# Altium schematic sheet Carte.SchDoc(Carte)
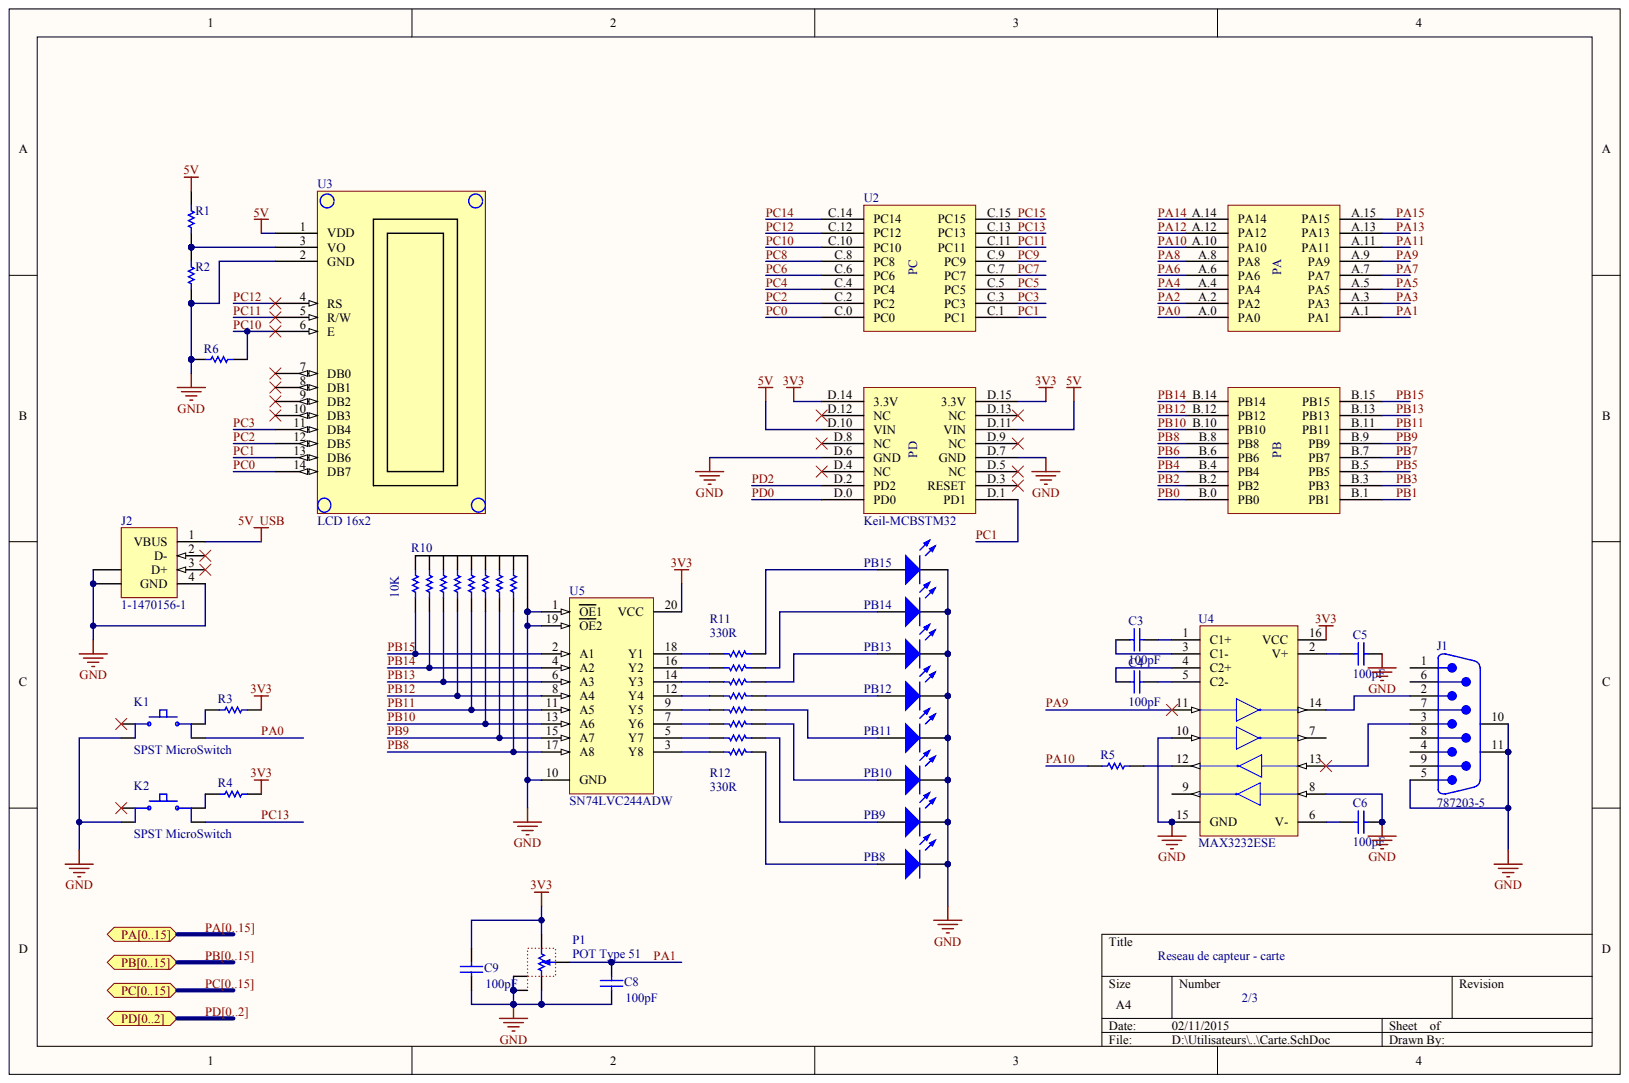
[diagram p0: Carte.SchDoc]

## Parts
C3  value 100pF  (hidden comment: C1206)
C4  value 100pF  (hidden comment: C1206)
C5  value 100pF  (hidden comment: C1206)
C6  value 100pF  (hidden comment: C1206)
C8  value 100pF  (hidden comment: C1206)
C9  value 100pF  (hidden comment: C1206)
J1  787203-5
J2  1-1470156-1
K1  SPST MicroSwitch
K2  SPST MicroSwitch
P1  POT Type 51
PB8  Led  (value not drawn)
PB9  Led  (value not drawn)
PB10  Led  (value not drawn)
PB11  Led  (value not drawn)
PB12  Led  (value not drawn)
PB13  Led  (value not drawn)
PB14  Led  (value not drawn)
PB15  Led  (value not drawn)
R1  ERJ8EN  (value not drawn)
R2  ERJ8EN  (value not drawn)
R3  ERJ8EN  (value not drawn)
R4  ERJ8EN  (value not drawn)
R5  ERJ8EN  (value not drawn)
R6  ERJ8EN  (value not drawn)
R10  value 10K  (hidden comment: Reseau-R Bus)
R11  value 330R  (hidden comment: Reseau-R Parallele)
R12  value 330R  (hidden comment: Reseau-R Parallele)
U2  Keil-MCBSTM32
U3  LCD 16x2
U4  MAX3232ESE
U5  SN74LVC244ADW

## Ports
PA0, PA1, PA2, PA3, PA4, PA5, PA6, PA7, PA8, PA9, PA10, PA11, PA12, PA13, PA14, PA15, PA[0..15], PB0, PB1, PB2, PB3, PB4, PB5, PB6, PB7, PB8, PB9, PB10, PB11, PB12, PB13, PB14, PB15, PB[0..15], PC0, PC1, PC2, PC3, PC4, PC5, PC6, PC7, PC8, PC9, PC10, PC11, PC12, PC13, PC14, PC15, PC[0..15], PD0, PD1, PD2, PD[0..2]

## Nets
3V3: C9.2 P1.1 R3.1 R4.1 U2.D.14(3.3V) U2.D.15 U4.16(VCC) U5.20(VCC)
5V: R1.2 U2.D.10(VIN) U2.D.11 U3.1(VDD)
5V_USB: J2.1(VBUS)
GND: C5.1 C6.1 C8.1 C9.1 J1.5 J1.10 J1.11 J2.4(GND) J2.5 J2.6 K1.2 K2.2 P1.3 P1.4 P1.5 PB8.K PB9.K PB10.K PB11.K PB12.K PB13.K PB14.K PB15.K R2.1 R6.1 R10.1 U2.D.6(GND) U2.D.7 U3.2(GND) U4.8 U4.10 U4.15(GND) U5.1(OE1) U5.10(GND) U5.19(OE2)
PA0: K1.4 U2.A.0(PA0) ; port PA[0..15]  [also labelled PA]
PA1: C8.2 P1.2 U2.A.1(PA1) ; port PA[0..15]  [also labelled PA]
PA2: U2.A.2(PA2) ; port PA[0..15]  [also labelled PA]
PA3: U2.A.3(PA3) ; port PA[0..15]  [also labelled PA]
PA4: U2.A.4 ; port PA[0..15]  [also labelled PA]
PA5: U2.A.5(PA5) ; port PA[0..15]  [also labelled PA]
PA6: U2.A.6 ; port PA[0..15]  [also labelled PA]
PA7: U2.A.7(PA7) ; port PA[0..15]  [also labelled PA]
PA8: U2.A.8 ; port PA[0..15]  [also labelled PA]
PA9: U2.A.9(PA9) U4.11 ; port PA[0..15]  [also labelled PA]
PA10: R5.2 U2.A.10 ; port PA[0..15]  [also labelled PA]
PA11: U2.A.11(PA11) ; port PA[0..15]  [also labelled PA]
PA12: U2.A.12 ; port PA[0..15]  [also labelled PA]
PA13: U2.A.13(PA13) ; port PA[0..15]  [also labelled PA]
PA14: U2.A.14 ; port PA[0..15]  [also labelled PA]
PA15: U2.A.15(PA15) ; port PA[0..15]  [also labelled PA]
PB0: U2.B.0 ; port PB[0..15]  [also labelled PB]
PB1: U2.B.1(PB1) ; port PB[0..15]  [also labelled PB]
PB2: U2.B.2 ; port PB[0..15]  [also labelled PB]
PB3: U2.B.3(PB3) ; port PB[0..15]  [also labelled PB]
PB4: U2.B.4 ; port PB[0..15]  [also labelled PB]
PB5: U2.B.5(PB5) ; port PB[0..15]  [also labelled PB]
PB6: U2.B.6 ; port PB[0..15]  [also labelled PB]
PB7: U2.B.7(PB7) ; port PB[0..15]  [also labelled PB]
PB8: R10.2 U2.B.8 U5.17(A8) ; port PB[0..15]  [also labelled PB]
PB9: R10.3 U2.B.9(PB9) U5.15(A7) ; port PB[0..15]  [also labelled PB]
PB10: R10.4 U2.B.10 U5.13(A6) ; port PB[0..15]  [also labelled PB]
PB11: R10.5 U2.B.11(PB11) U5.11(A5) ; port PB[0..15]  [also labelled PB]
PB12: R10.6 U2.B.12 U5.8(A4) ; port PB[0..15]  [also labelled PB]
PB13: R10.7 U2.B.13(PB13) U5.6(A3) ; port PB[0..15]  [also labelled PB]
PB14: R10.8 U2.B.14 U5.4(A2) ; port PB[0..15]  [also labelled PB]
PB15: R10.9 U2.B.15(PB15) U5.2(A1) ; port PB[0..15]  [also labelled PB]
PC0: U2.C.0(PC0) U3.14(DB7) ; port PC[0..15]  [also labelled PC]
PC1: U2.C.1(PC1) U2.D.1 U3.13(DB6) ; port PC[0..15]  [also labelled PC]
PC2: U2.C.2(PC2) U3.12(DB5) ; port PC[0..15]  [also labelled PC]
PC3: U2.C.3(PC3) U3.11(DB4) ; port PC[0..15]  [also labelled PC]
PC4: U2.C.4(PC4) ; port PC[0..15]  [also labelled PC]
PC5: U2.C.5 ; port PC[0..15]  [also labelled PC]
PC6: U2.C.6(PC6) ; port PC[0..15]  [also labelled PC]
PC7: U2.C.7 ; port PC[0..15]  [also labelled PC]
PC8: U2.C.8(PC8) ; port PC[0..15]  [also labelled PC]
PC9: U2.C.9 ; port PC[0..15]  [also labelled PC]
PC10: R6.2 U2.C.10(PC10) U3.6(E) ; port PC[0..15]  [also labelled PC]
PC11: U2.C.11 U3.5(R/W) ; port PC[0..15]  [also labelled PC]
PC12: U2.C.12(PC12) U3.4(RS) ; port PC[0..15]  [also labelled PC]
PC13: K2.4 U2.C.13 ; port PC[0..15]  [also labelled PC]
PC14: U2.C.14(PC14) ; port PC[0..15]  [also labelled PC]
PC15: U2.C.15 ; port PC[0..15]  [also labelled PC]
PD0: U2.D.0(PD0) ; port PD[0..2]  [also labelled PD]
PD2: U2.D.2(PD2) ; port PD[0..2]  [also labelled PD]
NetC3_1: C3.1 U4.1(C1+)
NetC3_2: C3.2 U4.3(C1-)
NetC4_1: C4.1 U4.5(C2-)
NetC4_2: C4.2 U4.4(C2+)
NetC5_2: C5.2 U4.2(V+)
NetC6_2: C6.2 U4.6(V-)
NetJ1_2: J1.2 U4.14
NetJ1_3: J1.3 U4.13
NetK1_3: K1.3 R3.2
NetK2_3: K2.3 R4.2
NetPB8_A: PB8.A R12.2
NetPB9_A: PB9.A R12.4
NetPB10_A: PB10.A R12.6
NetPB11_A: PB11.A R12.8
NetPB12_A: PB12.A R11.2
NetPB13_A: PB13.A R11.4
NetPB14_A: PB14.A R11.6
NetPB15_A: PB15.A R11.8
NetR1_1: R1.1 R2.2 U3.3(VO)
NetR5_1: R5.1 U4.12
NetR11_1: R11.1 U5.12(Y4)
NetR11_3: R11.3 U5.14(Y3)
NetR11_5: R11.5 U5.16(Y2)
NetR11_7: R11.7 U5.18(Y1)
NetR12_1: R12.1 U5.3(Y8)
NetR12_3: R12.3 U5.5(Y7)
NetR12_5: R12.5 U5.7(Y6)
NetR12_7: R12.7 U5.9(Y5)
PD1: ; port PD[0..2]  [also labelled PD]

Not connected: J1 1 4 6 7 8 9; J2 2(D-) 3(D+); K1 1; K2 1; U2 D.3 D.4(NC) D.5 D.8(NC) D.9 D.12(NC) D.13; U3 7(DB0) 8(DB1) 9(DB2) 10(DB3); U4 7 9

## Library data of the parts
J1 = (no symbol name): Positions: 9; MatingConnector: 4-40 Threaded Insert; Gender: Plug; PCB_Layout: Complies with manufacturer's recommendation.; DrillSize: Corresponds to nominal value.; ComponentAvailability: Obsolete; Published: 15-Jun-2001; LatestRevisionDate: 17-Jul-2002; LatestRevisionNote: Re-released for DXP Platform.; DatasheetDocument: 19-Mar-1999; PackageDocument: 19-Mar-1999; PackageReference: 787203-5; Orientation: Right Angle; ShellSize: 1; ComponentLink1Description: Manufacturer Link; ComponentLink1URL: http://www.tycoelectronics.com/; Publisher: Altium Limited
J2 = (no symbol name): ContactMaterial: Phosphor Bronze; ContactPlating: Gold over Nickel; TerminationLength: 3.00mm [0.118in.]; PCB_Layout: Complies with manufacturer's recommendation.; DrillSize: Corresponds to nominal value.; PanelGround: Without; Published: 15-Jul-2004; DatasheetDocument: 02-Jun-2001; PackageDocument: 02-Jun-2001; PackageReference: 1-1470156; HousingMaterial: Glass-Filled Thermoplastic; ComponentLink1Description: Manufacturer Link; ComponentLink1URL: http://www.tycoelectronics.com/; ComponentLink2Description: Packaging Specification; ComponentLink2URL: http://ecommas.tycoelectronics.com/commerce/DocumentDelivery/DDEController?Action=showdoc&DocId=Customer+Drawing%7F1470156%7FO%7Ftif%7FEnglish%7FC_1470156_O.tif; Publisher: Altium Limited
C3-C6 C8 C9 K1 K2 P1 PB8-PB15 R1-R6 R10-R12 U2-U5 = (no symbol name)
  C3-C6 C8 C9: Published: 17-Jul-2002; LatestRevisionDate: 19-Mar-2004; LatestRevisionNote: Silkscreen size reduced.; DatasheetDocument: Mar-2001; PackageDocument: Mar-2001; PackageReference: 1206; Code_JEITA: 1206; Code_IPC: 3216 [1206]; ComponentLink1Description: Manufacturer Link; ComponentLink1URL: http://www.kemet.com/; ComponentLink2Description: Datasheet; ComponentLink2URL: http://www.kemet.com/kemet/web/homepage/kechome.nsf/vapubfilesname/F3102TA.pdf/$file/F3102TA.pdf
  R1-R6: Published: 17-Dec-2003; LatestRevisionDate: 19-Mar-2004; LatestRevisionNote: Silkscreen size reduced.; PackageDocument: Mar-2003; PackageReference: 8-1206; Code_IPC: 3216 [1206]; ComponentLink1Description: Manufacturer Link; ComponentLink1URL: http://www.panasonic.com/industrial/components/resistive_products.htm; ComponentLink2Description: Datasheet; ComponentLink2URL: http://www.panasonic.com/industrial/components/pdf/AOA0000CE2.pdf
  U4: Published: 16-Jan-2001; LatestRevisionDate: 13-Apr-2006; LatestRevisionNote: IPC-7351 Footprint Added.; DatasheetVersion: Mar-1999; PackageReference: NSO16; PackageDescription: 16-Pin Small Outline Integrated Circuit 1.27 mm Pitch; Code_JEDEC: MS-012-AC; Code_IPC: SOIC127P600-16; ComponentLink1Description: Manufacturer Link; ComponentLink1URL: http://www.maxim-ic.com/; ComponentLink2Description: Datasheet; ComponentLink2URL: http://pdfserv.maxim-ic.com/en/ds/MAX3222-MAX3241.pdf
  U5: Published: 8-Jun-2000; LatestRevisionDate: 13-Apr-2006; LatestRevisionNote: IPC-7351 Footprint Added.; DatasheetVersion: Apr-1999; PackageVersion: Mar-2000; PackageReference: DW020; PackageDescription: 20-Pin Plastic Small Outline Integrated Circuit 1.27 mm Pitch; Code_JEDEC: MS-013; Code_IPC: SOIC127P1030-20; ComponentLink1Description: Manufacturer Link; ComponentLink1URL: http://www.ti.com/; ComponentLink2Description: Datasheet; ComponentLink2URL: http://www-s.ti.com/sc/ds/sn74lvc244a.pdf
  C3-C6 C8 C9 R1-R6 U4 U5: Publisher: Altium Limited
